# Altium schematic sheet POWER.SchDoc(POWER)
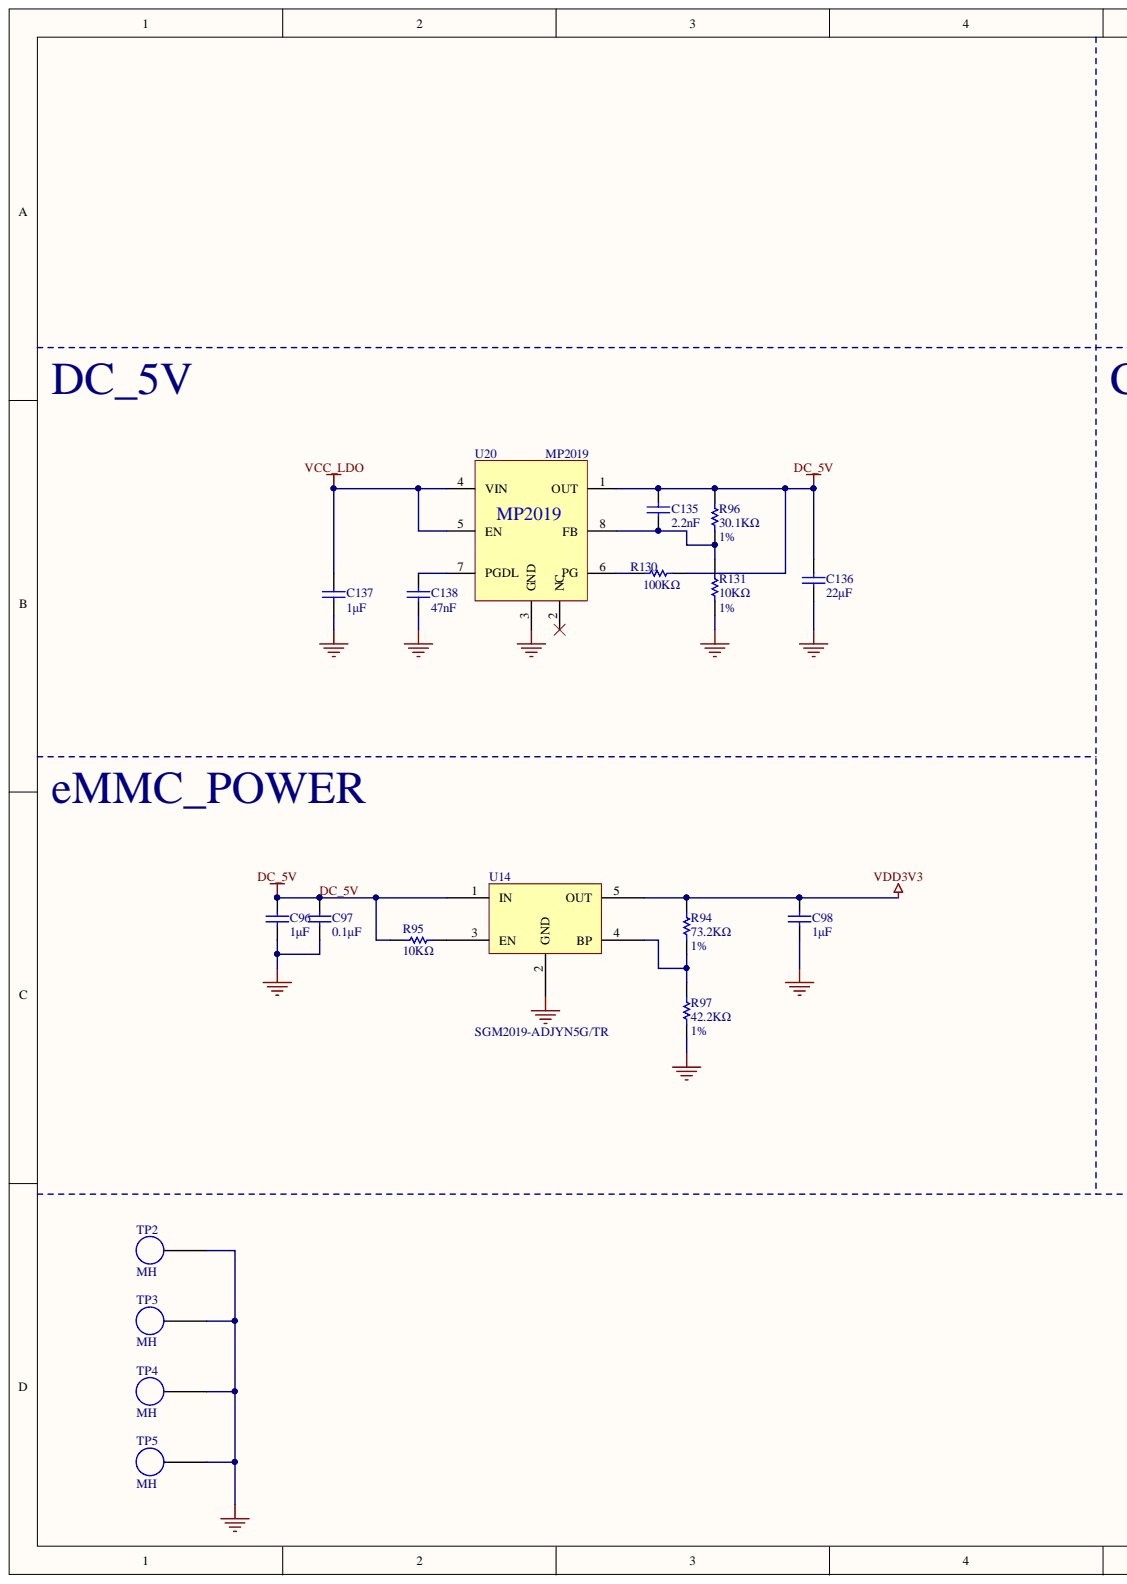
[diagram p0: POWER.SchDoc, left]
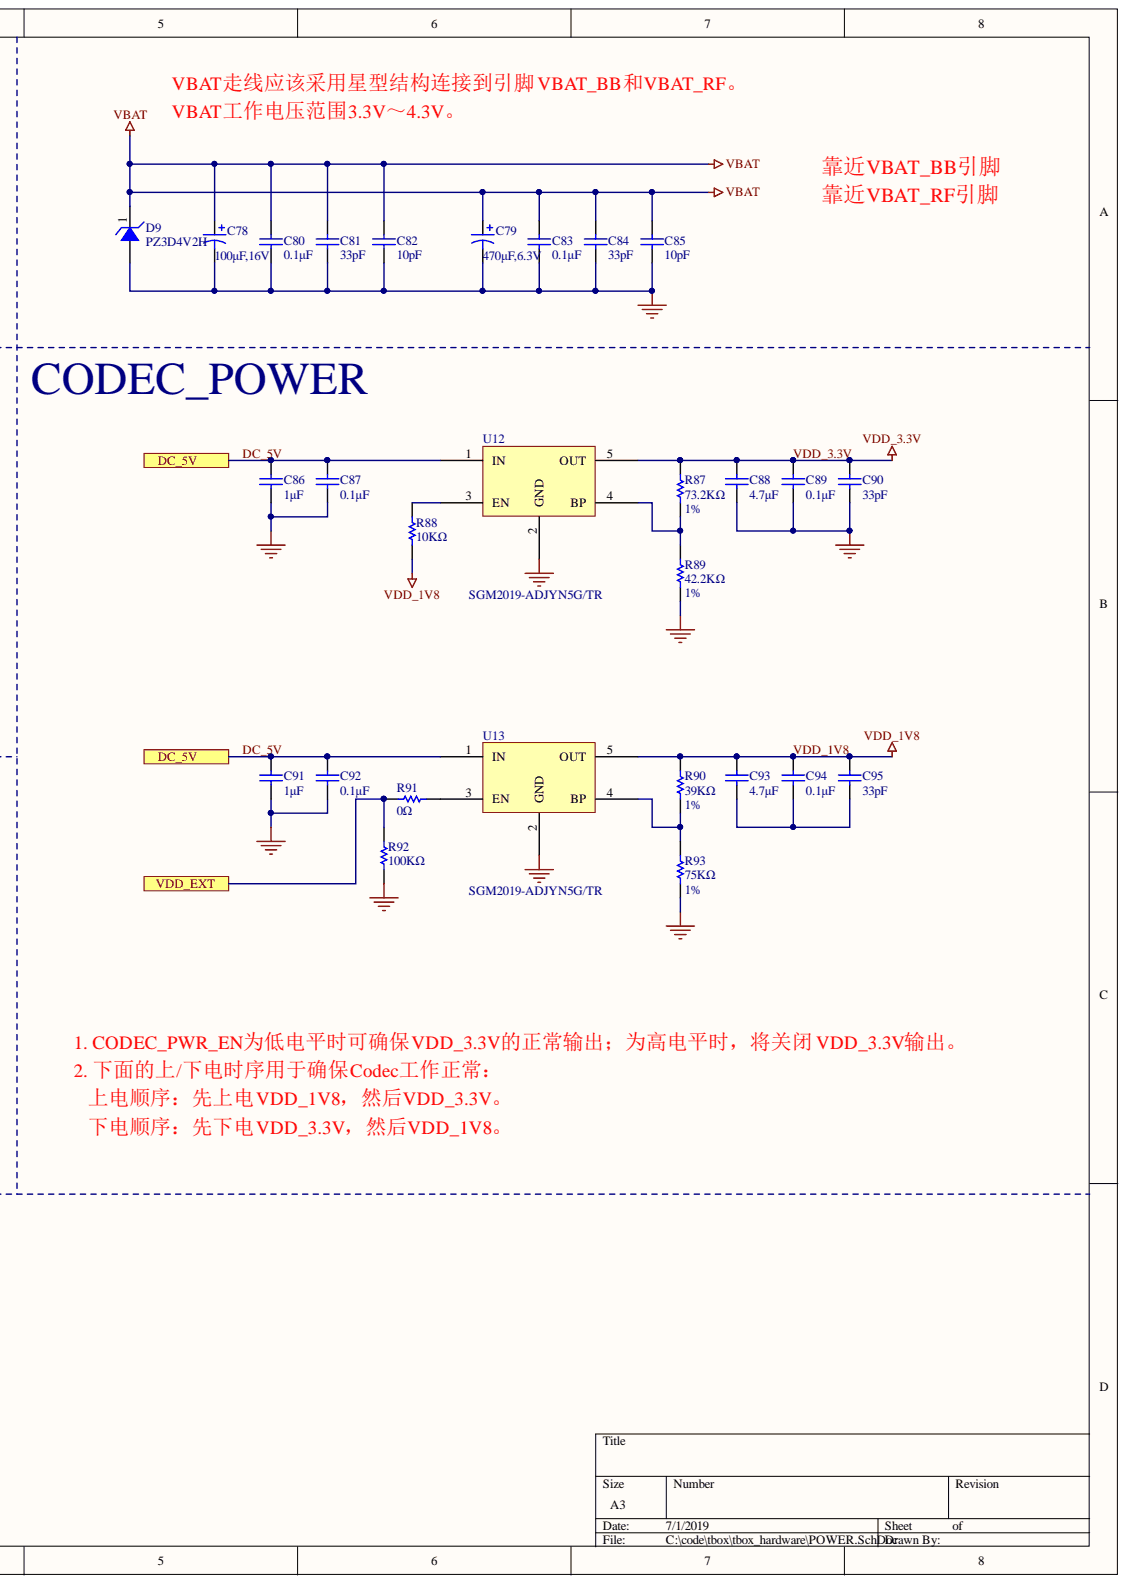
[diagram p1: POWER.SchDoc, right]

## Parts
C78  100μF,16V  [CAPAE-D63*54]
C79  470μF,6.3V  [CAPAE-D63*54]
C80  0.1μF  [C0402]
C81  33pF  [C0402]
C82  10pF  [C0402]
C83  0.1μF  [C0402]
C84  33pF  [C0402]
C85  10pF  [C0402]
C86  1μF  [C0402]
C87  0.1μF  [C0402]
C88  4.7μF  [C0402]
C89  0.1μF  [C0402]
C90  33pF  [C0402]
C91  1μF  [C0402]
C92  0.1μF  [C0402]
C93  4.7μF  [C0402]
C94  0.1μF  [C0402]
C95  33pF  [C0402]
C96  1μF  [C0402]
C97  0.1μF  [C0402]
C98  1μF  [C0402]
C135  2.2nF  [C0402]
C136  22μF  [C0402]
C137  1μF  [C0402]
C138  47nF  [C0402]
D9  PZ3D4V2H  [SOD-323]
R87  73.2KΩ  value1: 1%  [R0402]
R88  10KΩ  [R0402]
R89  42.2KΩ  value1: 1%  [R0402]
R90  39KΩ  value1: 1%  [R0402]
R91  0Ω  [R0402]
R92  100KΩ  [R0402]
R93  75KΩ  value1: 1%  [R0402]
R94  73.2KΩ  value1: 1%  [R0402]
R95  10KΩ  [R0402]
R96  30.1KΩ  value1: 1%  [R0402]
R97  42.2KΩ  value1: 1%  [R0402]
R130  100KΩ  [R0402]
R131  10KΩ  value1: 1%  [R0402]
TP2  MH  [Hole]
TP3  MH  [Hole]
TP4  MH  [Hole]
TP5  MH  [Hole]
U12  SGM2019-ADJYN5G/TR  [SOT23-5]
U13  SGM2019-ADJYN5G/TR  [SOT23-5]
U14  SGM2019-ADJYN5G/TR  [SOT23-5]
U20  MP2019  [SOIC-8_EP]

## Ports
DC_5V, VDD_EXT

## Nets
GND: C78.2 C79.2 C80.1 C81.1 C82.1 C83.1 C84.1 C85.1 C86.1 C87.1 C88.1 C89.1 C90.1 C91.1 C92.1 C96.1 C97.1 C98.1 C136.1 C137.1 C138.1 D9.2 R89.2 R92.2 R93.2 R97.2 R131.2 TP2.1 TP3.1 TP4.1 TP5.1 U12.2(GND) U13.2(GND) U14.2(GND) U20.3(GND)
VBAT: C78.1 C79.1 C80.2 C81.2 C82.2 C83.2 C84.2 C85.2 D9.1
VCC_LDO: C137.2 U20.4(VIN) U20.5(EN)
VDD3V3: C98.2 R94.1 U14.5(OUT)
DC_5V: C86.2 C87.2 C91.2 C92.2 C96.2 C97.2 C135.2 C136.2 R95.1 R96.1 R130.2 U12.1(IN) U13.1(IN) U14.1(IN) U20.1(OUT) ; port DC_5V
VDD_1V8: C93.2 C94.2 C95.2 R88.2 R90.1 U13.5(OUT)
VDD_3.3V: C88.2 C89.2 C90.2 R87.1 U12.5(OUT)
VDD_EXT: R91.1 R92.1 ; port VDD_EXT
NetC93_1: C93.1 C94.1 C95.1
NetC135_1: C135.1 R96.2 R131.1 U20.8(FB)
NetC138_2: C138.2 U20.7(PGDL)
NetR87_2: R87.2 R89.1 U12.4(BP)
NetR88_1: R88.1 U12.3(EN)
NetR90_2: R90.2 R93.1 U13.4(BP)
NetR91_2: R91.2 U13.3(EN)
NetR94_2: R94.2 R97.1 U14.4(BP)
NetR95_2: R95.2 U14.3(EN)
NetR130_1: R130.1 U20.6(PG)

Not connected: U20 2(NC)

## Library data of the parts
C78 C79 = Cap Pol2: Polarized Capacitor (Axial); Simulation: CAP; Signal Integrity: Cap Pol; Published: 8-Jun-2000; PackageReference: POLAR0.8; PackageDescription: Polarized Capacitor; 2 Leads; Library Name: Miscellaneous Devices.SchLib; LatestRevisionNote: Re-released for DXP Platform.; LatestRevisionDate: 17-Jul-2002; Component Kind: Standard; Publisher: Altium Limited
  C78: Value: 100μF,16V
  C79: Value: 470μF,6.3V
C80-C98 C135-C138 = Cap: Capacitor; Simulation: CAP; Signal Integrity: Cap; Published: 8-Jun-2000; PackageReference: RAD-0.3; PackageDescription: Radial Cap, Thru-Hole; 2 Leads; 0.3 in Pin Spacing; Library Name: Miscellaneous Devices.SchLib; LatestRevisionNote: Re-released for DXP Platform.; LatestRevisionDate: 17-Jul-2002; Component Kind: Standard; Publisher: Altium Limited
  C80 C83 C87 C89 C92 C94 C97: Value: 0.1μF
  C81 C84 C90 C95: Value: 33pF
  C82 C85: Value: 10pF
  C86 C91 C96 C98 C137: Value: 1μF
  C88 C93: Value: 4.7μF
  C135: Value: 2.2nF
  C136: Value: 22μF
  C138: Value: 47nF
D9 = D Zener: Zener Diode; Simulation: ZENER; Signal Integrity: D Zener; Published: 8-Jun-2000; PackageReference: DIODE-0.7; PackageDescription: Diode, Thru-Hole; 2 Leads; 0.7 in Pin Spacing; Library Name: Miscellaneous Devices.SchLib; LatestRevisionNote: Re-released for DXP Platform.; LatestRevisionDate: 17-Jul-2002; Component Kind: Standard; Publisher: Altium Limited
R87-R97 R130 R131 = Res1: Resistor; Simulation: RESISTOR; Signal Integrity: Res; Published: 8-Jun-2000; PackageReference: AXIAL-0.3; PackageDescription: Axial Device, Thru-Hole; 2 Leads; 0.3 in Pin Spacing; Library Name: Miscellaneous Devices.SchLib; LatestRevisionNote: Re-released for DXP Platform.; LatestRevisionDate: 17-Jul-2002; Component Kind: Standard; Publisher: Altium Limited
  R87 R94: Value: 73.2KΩ
  R88 R95 R131: Value: 10KΩ
  R89 R97: Value: 42.2KΩ
  R90: Value: 39KΩ
  R91: Value: 0Ω
  R92 R130: Value: 100KΩ
  R93: Value: 75KΩ
  R96: Value: 30.1KΩ
TP2-TP5 = TestPoint: Library Name: Miscellaneous Devices.SchLib; Component Kind: Standard
U12-U14 = SY6280: Low Power, Low Dropout,  RF-Linear Regulators; Library Name: Miscellaneous Devices.SchLib; Component Kind: Standard
U20 = MP2019: 40V, 300mA, Low Quiescent Current Adjustable Output Linear Regulator; Simulation: PLLx; Published: 8-Jun-2000; PackageVersion: May-1999; PackageReference: DB008; PackageDescription: 8-Pin Plastic Small Outline Package 0.65 mm Pitch; Library Name: Miscellaneous Devices.SchLib; LatestRevisionNote: IPC-7351 Footprint Added.; LatestRevisionDate: 29-May-2009; Component Kind: Standard; Code_JEDEC: MO-150-AA; Code_IPC: SOP65P780-8; Publisher: Altium Limited
